# Altium schematic sheet ESP32 MCU.SchDoc
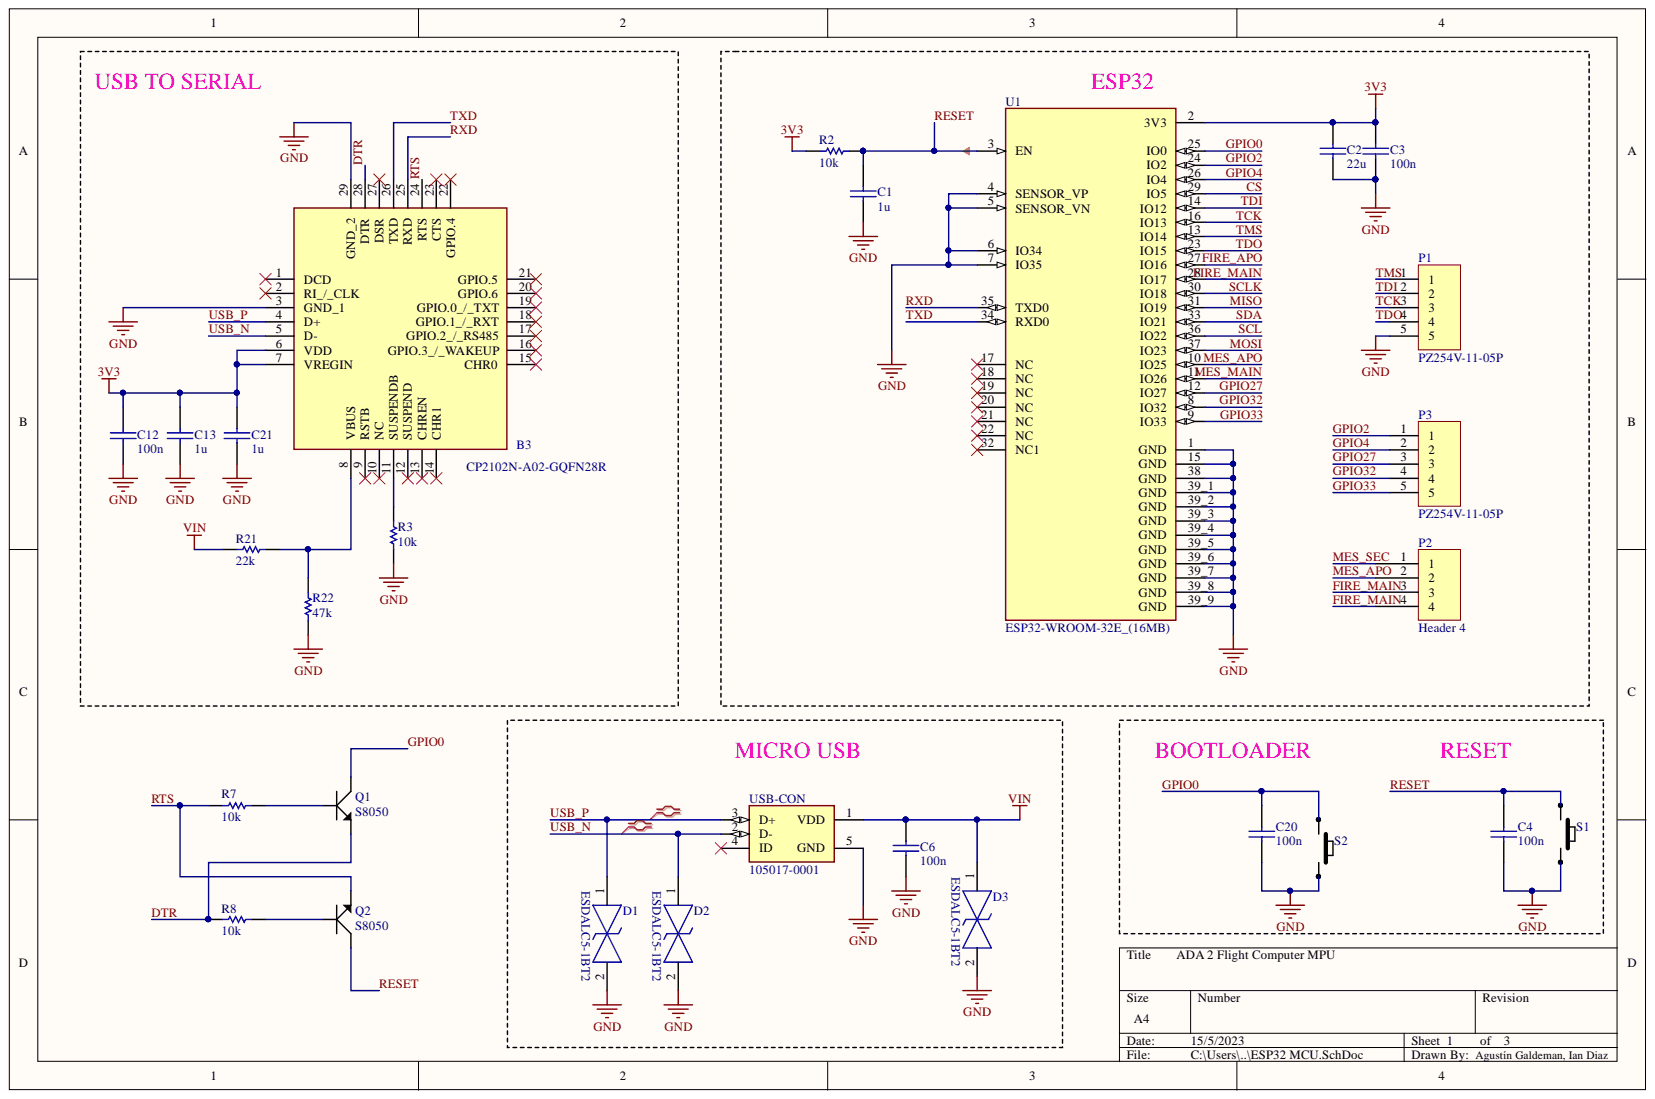
[diagram p0: ESP32 MCU.SchDoc]

## Parts
B3  CP2102N-A02-GQFN28R  [CP2102N]
C1  1u  [CAPSMD0805]
C2  22u  [CAPSMD0805]
C3  100n  [CAPSMD0805]
C4  100n  [CAPSMD0603]
C6  100n  [CAPSMD0805]
C12  100n  [CAPSMD0805]
C13  1u  [CAPSMD0805]
C20  100n  [CAPSMD0603]
C21  1u  [CAPSMD0805]
D1  ESDALC5-1BT2  [ESDALC51BT2]
D2  ESDALC5-1BT2  [ESDALC51BT2]
D3  ESDALC5-1BT2  [ESDALC51BT2]
P1  HEADER-5P-SR  [PZ254V-11-05P]  (value not drawn)
P2  Manufacturer Part Number: Header 4  [PZ254V-11-04P]  (hidden comment: PZ254V-11-04P)
P3  HEADER-5P-SR  [PZ254V-11-05P]  (value not drawn)
Q1  S8050  [SOT-23-3]
Q2  S8050  [SOT-23-3]
R2  10k  [RESSMD0805]
R3  10k  [RESSMD0805]
R7  10k  [RESSMD0805]
R8  10k  [RESSMD0805]
R21  22k  [RESSMD0805]
R22  47k  [RESSMD0805]
S1  PUSHBUTTON  [PUSHBUTTON]  (value not drawn)
S2  PUSHBUTTON  [PUSHBUTTON]  (value not drawn)
U1  ESP32-WROOM-32E_(16MB)  [XCVR_ESP32-WROOM-32E_(16MB)]
USB-CON  105017-0001  [MOLEX_105017-0001]

## Nets
3V3: B3.6(VDD) B3.7(VREGIN) C2.1 C3.1 C12.1 C13.1 C21.1 R2.2 U1.2(3V3)
GND: B3.3(GND_1) B3.29(GND_2) C1.2 C2.2 C3.2 C4.1 C6.2 C12.2 C13.2 C20.1 C21.2 D1.2 D2.2 D3.2 P1.5 R3.2 R22.1 S1.2 S2.2 U1.1(GND) U1.4(SENSOR_VP) U1.5(SENSOR_VN) U1.6(IO34) U1.7(IO35) U1.15(GND) U1.38(GND) U1.39_1(GND) U1.39_2(GND) U1.39_3(GND) U1.39_4(GND) U1.39_5(GND) U1.39_6(GND) U1.39_7(GND) U1.39_8(GND) U1.39_9(GND) USB-CON.5(GND)
VIN: C6.1 D3.1 R21.1 USB-CON.1(VDD)
CS: U1.29(IO5)
DTR: B3.28(DTR) Q1.2 R8.2
FIRE_APO: U1.27(IO16)
FIRE_MAIN: P2.3 P2.4 U1.28(IO17)
GPIO0: C20.2 Q1.3 S2.1 U1.25(IO0)
GPIO2: P3.1 U1.24(IO2)
GPIO4: P3.2 U1.26(IO4)
GPIO27: P3.3 U1.12(IO27)
GPIO32: P3.4 U1.8(IO32)
GPIO33: P3.5 U1.9(IO33)
MES_APO: P2.2 U1.10(IO25)
MES_MAIN: U1.11(IO26)
MES_SEC: P2.1
MISO: U1.31(IO19)
MOSI: U1.37(IO23)
RESET: C1.1 C4.2 Q2.3 R2.1 S1.1 U1.3(EN)
RTS: B3.24(RTS) Q2.2 R7.2
RXD: B3.25(RXD) U1.35(TXD0)
SCL: U1.36(IO22)
SCLK: U1.30(IO18)
SDA: U1.33(IO21)
TCK: P1.3 U1.16(IO13)
TDI: P1.2 U1.14(IO12)
TDO: P1.4 U1.23(IO15)
TMS: P1.1 U1.13(IO14)
TXD: B3.26(TXD) U1.34(RXD0)
USB_N: B3.5(D-) D2.1 USB-CON.2(D-)
USB_P: B3.4(D+) D1.1 USB-CON.3(D+)
NetB3_8: B3.8(VBUS) R21.2 R22.2
NetB3_11: B3.11(SUSPENDB) R3.1
NetQ1_1: Q1.1 R7.1
NetQ2_1: Q2.1 R8.1

Not connected: B3 1(DCD) 2(RI_/_CLK) 9(RSTB) 10(NC) 12(SUSPEND) 13(CHREN) 14(CHR1) 15(CHR0) 16(GPIO.3_/_WAKEUP) 17(GPIO.2_/_RS485) 18(GPIO.1_/_RXT) 19(GPIO.0_/_TXT) 20(GPIO.6) 21(GPIO.5) 22(GPIO.4) 23(CTS) 27(DSR); U1 17(NC) 18(NC) 19(NC) 20(NC) 21(NC) 22(NC) 32(NC1); USB-CON 4(ID)

## Library data of the parts
B3 = CP2102N-A02-GQFN28R: USB Interface IC USB to UART bridge - QFN28; Manufacturer_Name: Silicon Labs; Geometry.Height: 0.8mm; Component Kind: Standard; Datasheet Link: https://www.silabs.com/documents/public/data-sheets/cp2102n-datasheet.pdf; Library Name: ESP32 Platform.SchLib; Manufacturer_Part_Number: CP2102N-A02-GQFN28R; Mouser Part Number: 634-CP2102NA02QFN28R; Mouser Price/Stock: https://www.mouser.co.uk/ProductDetail/Silicon-Labs/CP2102N-A02-GQFN28R?qs=u16ybLDytRag4qKvSH3fTw%3D%3D
C1 C6 C12 C13 C21 = CAP: Capacitor SMD; Component Kind: Standard; Library Name: ESP32 Platform.SchLib
C2 C3 = CAP: Capacitor SMD 4.7nF; Component Kind: Standard; Library Name: ESP32 Platform.SchLib
C4 C20 = CAP: Capacitor SMD; Value: 10nF; Component Kind: Standard; Library Name: ESP32 Platform.SchLib
D1-D3 = ESDALC5-1BT2: ESD Suppressors / TVS Diodes Single Line Transil Vbr 5V min 26pF 0; Manufacturer_Name: STMicroelectronics; Component Kind: Standard; Datasheet Link: http://www.st.com/web/en/resource/technical/document/datasheet/CD00260064.pdf; Library Name: ESP32 Platform.SchLib; Manufacturer_Part_Number: ESDALC5-1BT2; Mouser Part Number: 511-ESDALC5-1BT2; Mouser Price/Stock: https://www.mouser.co.uk/ProductDetail/STMicroelectronics/ESDALC5-1BT2?qs=z0iXaKah%2FHDPGBBIoqx%252BQw%3D%3D
P1 P3 = HEADER-5P-SR: Manufacturer: XFCN; Component Kind: Standard; Library Name: ESP32 Platform.SchLib; Manufacturer Part Number: PZ254V-11-05P; Supplier: JLCPCB; Supplier Part Number: C492404
P2 = PZ254V-11-04P: Straight Square Pins 2.5mm 6mm -40℃~+105℃ 1 3mm 4 2.54mm Black Brass 1x4P Straight,P=2.54mm  Pin Headers ROHS; Manufacturer: XFCN; Component Kind: Standard; Library Name: ESP32 Platform.SchLib; Supplier: JLCPCB; Supplier Part Number: C492403
Q1 Q2 = S8050: Manufacturer: JSMSEMI; Component Kind: Standard; Library Name: ESP32 Platform.SchLib; Manufacturer Part Number: S8050; Supplier: JLCPCB; Supplier Part Number: C916390
R2 R3 R7 R8 R21 R22 = RES: Resistencia SMD 10kohms; Component Kind: Standard; Library Name: ESP32 Platform.SchLib
S1 S2 = PUSHBUTTON: NO 6mm Gull Wing 4.3mm Black Round Button Brick nogging SPST 6mm 180gf@±30gf SMD  Tactile Switches ROHS; Manufacturer: Yuandi; Manufacturer Part Number: TS-1095PS-A1B2-C3D2; Component Kind: Standard; Library Name: ESP32 Platform.SchLib; Supplier: JLCPCB; Supplier Part Number: C2834918
U1 = ESP32-WROOM-32E_(16MB): Bluetooth, WiFi 802.11b/g/n, Bluetooth v4.2 +EDR, Class 1, 2 and 3 Transceiver Module 2.4GHz ~ 2.5GHz Integrated, Trace Surface Mount; MF: Espressif Systems; MP: ESP32-WROOM-32E (16MB); Availability: Not in stock; Check_prices: https://www.snapeda.com/parts/ESP32-WROOM-32E%20(16MB)/Espressif+Systems/view-part/?ref=eda; Component Kind: Standard; Library Name: ESP32 Platform.SchLib; Package: None; Price: None; SnapEDA_Link: https://www.snapeda.com/parts/ESP32-WROOM-32E%20(16MB)/Espressif+Systems/view-part/?ref=snap
USB-CON = 105017-0001: USB - micro B USB 2.0 Receptacle Connector 5 Position Surface Mount, Right Angle; Through Hole; MF: Molex; Availability: In Stock; Check_prices: https://www.snapeda.com/parts/105017-0001/Molex/view-part/?ref=eda; Component Kind: Standard; Library Name: ESP32 Platform.SchLib; MP: 105017-0001; Package: None; Price: None; Purchase-URL: https://pricing.snapeda.com/search/part/105017-0001/?ref=eda; SnapEDA_Link: https://www.snapeda.com/parts/105017-0001/Molex/view-part/?ref=snap
